# Altium schematic sheet Sheet1.SchDoc
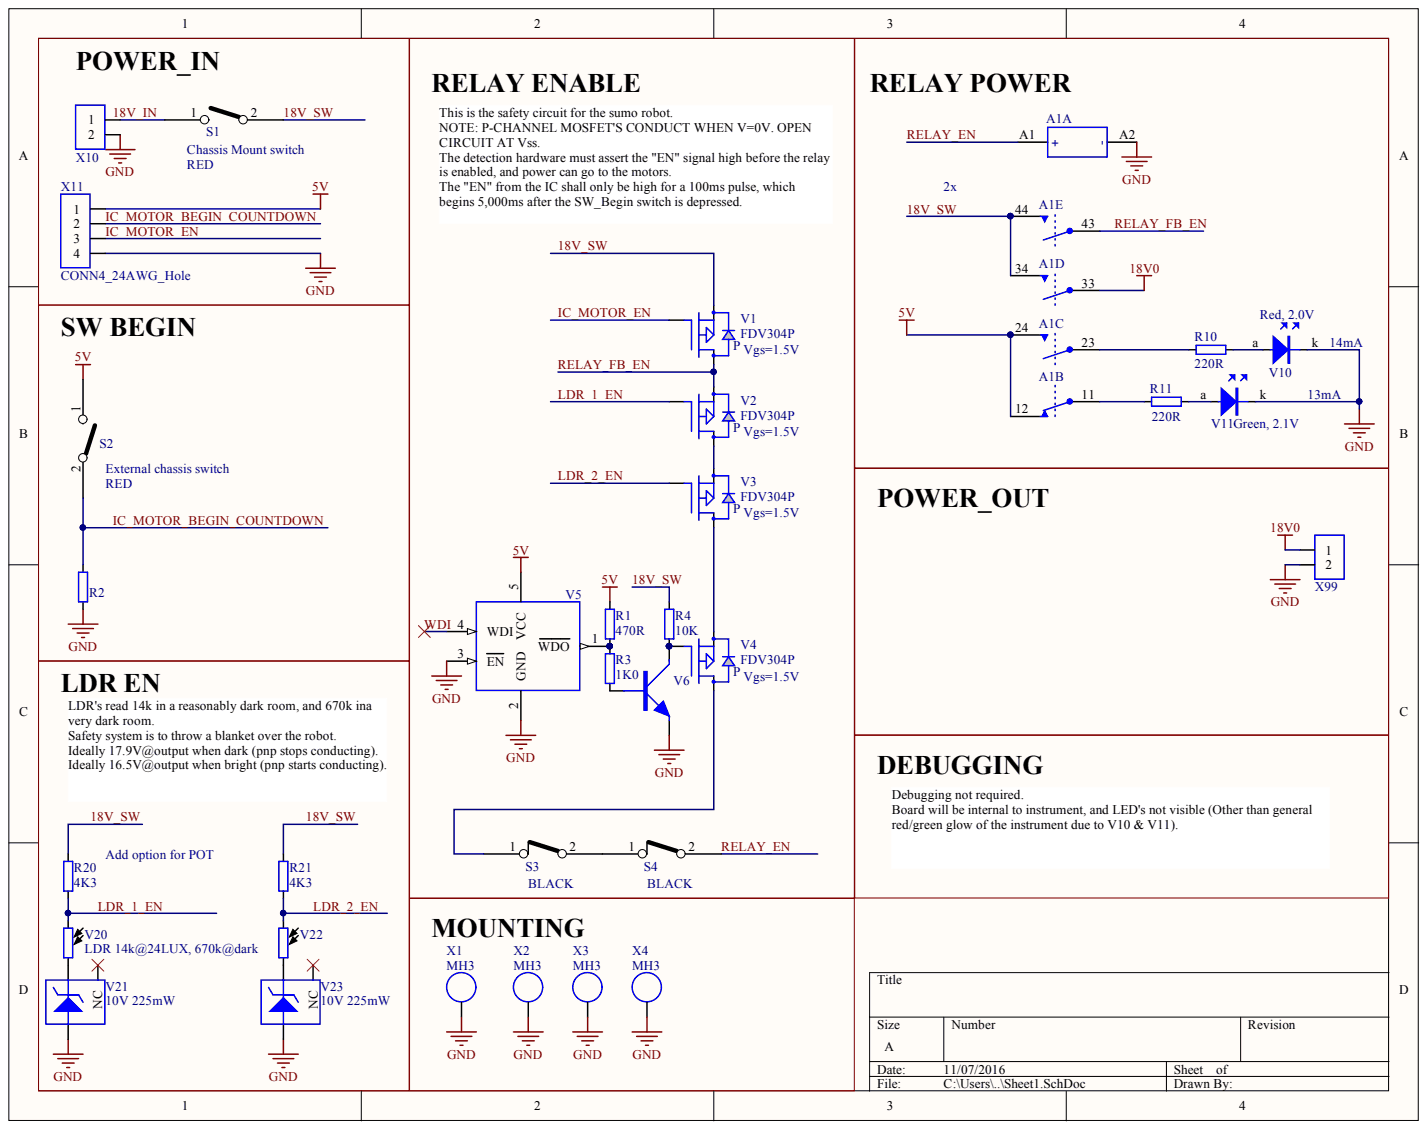
[diagram p0: Sheet1.SchDoc]

## Parts
A1  RELAY SAFETY 4PST 8A 18V  [RELAY-BRUMFIELD-4PST-3NO-1NC]  (value not drawn)  (parts A,B,C,D,E)
R1  470R  [1206]
R2  10K  [1206]  (value not drawn)
R3  1K0  [1206]
R4  10K  [1206]
R10  220R  [1206]
R11  220R  [1206]
R20  4K3  [0603]
R21  4K3  [0603]
S1  SWITCH Rocker SPST Panel Mount, Red  [2_Wire_12AWG]  (value not drawn)
S2  Switch NO  [2_Wire_12AWG]  (value not drawn)
S3  Switch NC  [2_Wire_20AWG]  (value not drawn)
S4  Switch NC  [2_Wire_20AWG]  (value not drawn)
V1  FDV304P  [SOT23]
V2  FDV304P  [SOT23]
V3  FDV304P  [SOT23]
V4  FDV304P  [SOT23]
V5  STWD100NYWY3F  [SOT23-5]  (value not drawn)
V6  BC846B  [SOT23]  (value not drawn)
V10  Red, 2.0V  [LED-0805]
V11  Green, 2.1V  [LED-0805]
V20  LDR 14k@24LUX, 670k@dark  [LDR_NSL-5112]
V21  10V 225mW  [SOT23]
V22  LDR 14k@24LUX, 670k@dark  [LDR_NSL-5112]
V23  10V 225mW  [SOT23]
X1  MH3  [MH-M3-SEMS-6.7-3.6-Vias-Unplated]  (no BOM)
X2  MH3  [MH-M3-SEMS-6.7-3.6-Vias-Unplated]  (no BOM)
X3  MH3  [MH-M3-SEMS-6.7-3.6-Vias-Unplated]  (no BOM)
X4  MH3  [MH-M3-SEMS-6.7-3.6-Vias-Unplated]  (no BOM)
X10  CONN2_12AWG_Hole  [2_Wire_12AWG]  (value not drawn)  (no BOM)
X11  CONN4_24AWG_Hole  [4_Wire_0.1"]  (no BOM)
X99  CONN2_12AWG_Hole  [2_Wire_12AWG]  (value not drawn)  (no BOM)

## Nets
5V: A1.12 A1.24 R1.1 S2.1 V5.5(VCC) X11.1
18V0: A1.33 X99.1
GND: A1.A2 R2.1 V5.2(GND) V5.3(EN) V6.y V10.k V11.k V21.z V23.z X1.1 X2.1 X3.1 X4.1 X10.2 X11.4 X99.2
18V_SW: A1.34 A1.44 R4.2 R20.2 R21.2 S1.2 V1.y
IC_MOTOR_BEGIN_COUNTDOWN: R2.2 S2.2 X11.2
IC_MOTOR_EN: V1.z X11.3
LDR_1_EN: R20.1 V2.z V20.1
LDR_2_EN: R21.1 V3.z V22.1
RELAY_EN: A1.A1(+) S4.2
RELAY_FB_EN: A1.43 V1.x V2.y
WDI: V5.4(WDI)
NetA1_11: A1.11 R11.1
NetA1_23: A1.23 R10.1
NetR1_2: R1.2 R3.2 V5.1(WDO)
NetR3_1: R3.1 V6.z
NetR4_1: R4.1 V4.z V6.x
NetR10_2: R10.2 V10.a
NetR11_2: R11.2 V11.a
NetS1_1: S1.1 X10.1
NetS3_1: S3.1 V4.x
NetS3_2: S3.2 S4.1
NetV2_x: V2.x V3.y
NetV3_x: V3.x V4.y
NetV20_2: V20.2 V21.x
NetV22_2: V22.2 V23.x
18V_IN:

Not connected: V21 y; V23 y

## Library data of the parts
A1 = 9827: Safety Relay 4PST, 3NO 1 NC; Manufacturer: TE Connectivity Potter & Brumfield Relays; Characteristics: 18VDC 405ohm  1250VAC/8A-Switching-load; Database Table Name: Active; DeviceRank: 2; Lead_Process_Compatible: Yes; OrderNumber: SR4M4018; SubmittedBy: zmze; Symbol: RELAY-4PST-3A1B; Altium_Comment: RELAY SAFETY 4PST 8A 18V; Altium_Comment_Old: RELAY SAFETY 4PST 8A 18V; Component Kind: Standard; ComponentLink1Description: TE Connectivity Potter & Brumfield Relays_SR4M4018_ENG_DS_SR4_DXM_0415.pdf; ComponentLink1URL: http://ecad.vsl.com.au/apps/BomGen/Data/rel/datasheets/TE Connectivity Potter & Brumfield Relays/TE Connectivity Potter & Brumfield Relays_SR4M4018_ENG_DS_SR4_DXM_0415.pdf; ComponentLink2Description: Compliant; IPN: 9827; IPN_Search: 9827; LastChanged: 27/05/16 08:10:39; Part Field 1: RELAY SAFETY 4PST 8A 18V; Part Field 2: TE Connectivity Potter & Brumfield Relays SR4M4018; PartNumber: SR4M4018; PartType: PCB; Supplier1: Digikey; Supplier1_PartNumber: PB1304-ND; Library Name: SVN_BOMGEN_Database_2014.DbLib
R1 = 3835: Resistor; Manufacturer: Phycomp; Part Field 1: RES 470R 1% 250mW 1206 RoHS; PartNumber: RC1206FR-07470RL; Characteristics: 100ppm/C -55..+155C U=150Vrms Reel=5000pcs; Database Table Name: Active; DeviceRank: 2; EndOfLife: Active EOL:Jan 2023; Lead_Process_Compatible: Yes; OrderNumber: 232272464701L; SubmittedBy: ART; Symbol: RES; Altium_Comment: 470R; Altium_Comment_Old: RES 470R 1% 250mW 1206 RoHS; Component Kind: Standard; ComponentLink1Description: Phycomp_RC1206series_DS.pdf; ComponentLink1URL: http://ecad.vsl.com.au/apps/BomGen/Data/rel/datasheets/new_parts/Phycomp_RC1206series_DS.pdf; ComponentLink2Description: Phycomp_Generic_RCS.pdf; ComponentLink2URL: http://ecad.vsl.com.au/apps/BomGen/Data/rel/rohs_compliance/new_certificates/Phycomp_Generic_RCS.pdf; IPN: 3835; IPN_Search: 3835; Part Field 2: Phycomp RC1206FR-07470RL; PartType: PCB; Price_5000: M$0.004; Supplier1: Arrow; Supplier1_PartNumber: RC1206FR-07470RL; Supplier2: Xon; CheckedBy: ART; Library Name: SVN_BOMGEN_Database_2014.DbLib
R2 R4 = 3867: Resistor; Manufacturer: Phycomp; Part Field 1: RES 10K 1% 250mW 1206 RoHS; PartNumber: RC1206FR-0710KL; Characteristics: 100ppm/C -55..+155C U=150Vrms Reel=5000pcs; Database Table Name: Active; DeviceRank: 2; EndOfLife: Active EOL:Jan 2031; Lead_Process_Compatible: Yes; OrderNumber: 232272461003L; SubmittedBy: ART; Symbol: RES; Altium_Comment: 10K; Altium_Comment_Old: RES 10K 1% 250mW 1206 RoHS; Component Kind: Standard; ComponentLink1Description: Phycomp_RC1206series_DS.pdf; ComponentLink1URL: http://ecad.vsl.com.au/apps/BomGen/Data/rel/datasheets/new_parts/Phycomp_RC1206series_DS.pdf; ComponentLink2Description: Phycomp_Generic_RCS.pdf; ComponentLink2URL: http://ecad.vsl.com.au/apps/BomGen/Data/rel/rohs_compliance/new_certificates/Phycomp_Generic_RCS.pdf; IPN: 3867; IPN_Search: 3867; Part Field 2: Phycomp RC1206FR-0710KL; PartType: PCB; Price_5000: M$0.004; Supplier1: Arrow; Supplier1_PartNumber: RC1206FR-0710KL; Supplier2: Xon; CheckedBy: ART; Library Name: SVN_BOMGEN_Database_2014.DbLib
R3 = 3843: Resistor; Manufacturer: Phycomp; Part Field 1: RES 1K0 1% 250mW 1206 RoHS; PartNumber: RC1206FR-071KL; Characteristics: 100ppm/C -55..+155C U=150Vrms Reel=5000pcs; Database Table Name: Active; DeviceRank: 2; EndOfLife: Active EOL:Jan 2031; Lead_Process_Compatible: Yes; OrderNumber: 232272461002L; SubmittedBy: ART; Symbol: RES; Altium_Comment: 1K0; Altium_Comment_Old: RES 1K0 1% 250mW 1206 RoHS; Component Kind: Standard; ComponentLink1Description: Phycomp_RC1206series_DS.pdf; ComponentLink1URL: http://ecad.vsl.com.au/apps/BomGen/Data/rel/datasheets/new_parts/Phycomp_RC1206series_DS.pdf; ComponentLink2Description: Phycomp_Generic_RCS.pdf; ComponentLink2URL: http://ecad.vsl.com.au/apps/BomGen/Data/rel/rohs_compliance/new_certificates/Phycomp_Generic_RCS.pdf; IPN: 3843; IPN_Search: 3843; Part Field 2: Phycomp RC1206FR-071KL; PartType: PCB; Price_5000: M$0.004; Supplier1: Arrow; Supplier1_PartNumber: RC1206FR-071KL; Supplier2: Xon; CheckedBy: ART; Library Name: SVN_BOMGEN_Database_2014.DbLib
R10 R11 = 3827: Resistor; Manufacturer: Phycomp; Part Field 1: RES 220R 1% 250mW 1206 RoHS; PartNumber: RC1206FR-07220RL; Characteristics: 100ppm/C -55..+155C U=150Vrms Reel=5000pcs; Database Table Name: Active; DeviceRank: 2; EndOfLife: Active EOL:Jan 2023; Lead_Process_Compatible: Yes; OrderNumber: 232272462201L; SubmittedBy: ART; Symbol: RES; Altium_Comment: 220R; Altium_Comment_Old: RES 220R 1% 250mW 1206 RoHS; Component Kind: Standard; ComponentLink1Description: Phycomp_RC1206series_DS.pdf; ComponentLink1URL: http://ecad.vsl.com.au/apps/BomGen/Data/rel/datasheets/new_parts/Phycomp_RC1206series_DS.pdf; ComponentLink2Description: Phycomp_Generic_RCS.pdf; ComponentLink2URL: http://ecad.vsl.com.au/apps/BomGen/Data/rel/rohs_compliance/new_certificates/Phycomp_Generic_RCS.pdf; IPN: 3827; IPN_Search: 3827; Part Field 2: Phycomp RC1206FR-07220RL; PartType: PCB; Price_5000: M$0.004; Supplier1: Arrow; Supplier1_PartNumber: RC1206FR-07220RL; Supplier2: Xon; CheckedBy: ART; Library Name: SVN_BOMGEN_Database_2014.DbLib
R20 R21 = 3520: Resistor; Manufacturer: Phycomp; PartNumber: RC0603FR-074K3L; AltDesc1: RES 4K3 1% 100mW 0603 RoHS; AltEOL1: Active EOL:Jan 2023; AltIPN1: 6663; AltMFG1: PANASONIC; AltPN1: ERJ3EKF4301V; Characteristics: 100ppm/C -55..+155C U=50Vrms Reel=5000pcs; Database Table Name: Active; DeviceRank: 1; Lead_Process_Compatible: Yes; OrderNumber: 232270464302L; SubmittedBy: ART; Symbol: RES; Altium_Comment: 4K3; Altium_Comment_Old: RES 4K3 1% 100mW 0603 RoHS; AltROHS1: pa003.pdf; Component Kind: Standard; ComponentLink1Description: Phycomp_RC0603series_DS.pdf; ComponentLink1URL: http://ecad.vsl.com.au/apps/BomGen/Data/rel/datasheets/new_parts/Phycomp_RC0603series_DS.pdf; ComponentLink2Description: Phycomp_Generic_RCS.pdf; ComponentLink2URL: http://ecad.vsl.com.au/apps/BomGen/Data/rel/rohs_compliance/new_certificates/Phycomp_Generic_RCS.pdf; EndOfLife: Active EOL:Jan 2023; IPN: 3520; IPN_Search: 3520; LastChanged: 28/11/07 14:44:00; Part Field 1: RES 4K3 1% 100mW 0603 RoHS; Part Field 2: Phycomp RC0603FR-074K3L; PartType: PCB; Price_5000: M$0.002; Supplier1: Arrow; Supplier1_PartNumber: RC0603FR-074K3L; Supplier2: Xon; Supplier2_PartNumber: 232270464302L; CheckedBy: ART; Library Name: SVN_BOMGEN_Database_2014.DbLib
S1 = 9815: SWITCH; Manufacturer: Cherry; Characteristics: V=125Vac, I=20A; Database Table Name: Active; DeviceRank: 2; Lead_Process_Compatible: Yes; OrderNumber: CRE22F2BBRLE; SubmittedBy: presi; Symbol: Switch_SPST-NO; Altium_Comment: SWITCH Rocker SPST Panel Mount, Red; Altium_Comment_Old: SWITCH Rocker SPST Panel Mount, Red; Component Kind: Standard; ComponentLink1Description: -; ComponentLink1URL: -; ComponentLink2Description: Compliant; ComponentLink2URL: -; IPN: 9815; IPN_Search: 9815; LastChanged: 13-06-16 21:14:44; Part Field 1: SWITCH Rocker SPST Panel Mount, Red; Part Field 2: Cherry CRE22F2BBRLE; PartNumber: CRE22F2BBRLE; PartType: PCB; Supplier1: DigiKey; Supplier1_PartNumber: CH809-ND; Library Name: SVN_BOMGEN_Database_2014.DbLib
S2 = 9814: SWITCH; Manufacturer: TE Connectivity; Part Field 1: Switch, Panel Mount, Momentary, SPST-NO, 0.5A 125V, RoHS; Characteristics: 0.5A 125V; Database Table Name: Active; DeviceRank: 2; Lead_Process_Compatible: Yes; OrderNumber: 1437568-6; SubmittedBy: presi; Symbol: Switch_SPST-NO; Altium_Comment: Switch NO; Altium_Comment_Old: Switch, Panel Mount, Momentary, SPST-NO, 0.5A 125V, RoHS; Component Kind: Standard; ComponentLink1Description: -; ComponentLink1URL: -; ComponentLink2Description: Compliant; ComponentLink2URL: -; IPN: 9814; IPN_Search: 9814; LastChanged: 13-06-16 21:00:15; Part Field 2: TE Connectivity 1437568-6; PartNumber: 1437568-6; PartType: PCB; Supplier1: Digi-Key; Supplier1_PartNumber: 450-1110-ND; Library Name: SVN_BOMGEN_Database_2014.DbLib
S3 S4 = 9813: SWITCH; Manufacturer: TE Connectivity; Part Field 1: Switch, Panel Mount, Momentary, SPST-NC, 0.5A 125V, RoHS; Characteristics: 0.5A 125V; Database Table Name: Active; DeviceRank: 2; Lead_Process_Compatible: Yes; OrderNumber: MSPS103B; SubmittedBy: presi; Symbol: Switch_SPST-NC; Altium_Comment: Switch NC; Altium_Comment_Old: Switch, Panel Mount, Momentary, SPST-NC, 0.5A 125V, RoHS; Component Kind: Standard; ComponentLink1Description: -; ComponentLink1URL: -; ComponentLink2Description: Compliant; ComponentLink2URL: -; IPN: 9813; IPN_Search: 9813; LastChanged: 13-06-16 20:48:49; Part Field 2: TE Connectivity MSPS103B; PartNumber: MSPS103B; PartType: PCB; Supplier1: Digi-Key; Supplier1_PartNumber: 450-1099-ND; Library Name: SVN_BOMGEN_Database_2014.DbLib
V1-V4 = 5221: MOSFET P CHANNEL GENERIC SOT23; Manufacturer: Fairchild; Part Field 1: MOSFET P-CH FDV304P SOT23; Characteristics: 25V 0.46A 1.5R Vgs=1.5V 150deg; Database Table Name: Active; DeviceRank: 2; EndOfLife: Active EOL:Jul 2022; Lead_Process_Compatible: Yes; SubmittedBy: KZB; Symbol: MOSFET-P-SOT23; Altium_Comment: FDV304P; Altium_Comment_Old: MOSFET P-CH FDV304P SOT23; Component Kind: Standard; ComponentLink1Description: Fairchild_FDV304P_DS.pdf; ComponentLink1URL: http://ecad.vsl.com.au/apps/BomGen/Data/rel/datasheets/new_parts/Fairchild_FDV304P_DS.pdf; ComponentLink2Description: Fairchild_Generic_RCS.pdf; ComponentLink2URL: http://ecad.vsl.com.au/apps/BomGen/Data/rel/rohs_compliance/new_certificates/Fairchild_Generic_RCS.pdf; IPN: 5221; IPN_Search: 5221; LastChanged: 10/06/10 13:58:43; OrderNumber: FDV304P; Part Field 2: Fairchild FDV304P; PartNumber: FDV304P; PartType: PCB; Price: 0.697; Price_100: M$0.102; Price_1000: M$0.070; Price_5000: M$0.053; Supplier1: Digikey; Supplier1_PartNumber: FDV304PCT-ND; Supplier2: Farnell; Supplier2_PartNumber: 9846123; CheckedBy: AJR; Library Name: SVN_BOMGEN_Database_2014.DbLib
V5 = 5674: IC Watchdog Timer Circuit; Manufacturer: ST Microelectronics; Part Field 1: Microprocessor Watchdog Timer STWD100NY; Characteristics: Open Drain Output 210ms; Database Table Name: Active; DeviceRank: 2; EndOfLife: Active EOL:Dec 2024; Lead_Process_Compatible: Yes; SubmittedBy: GRH; Altium_Comment: STWD100NYWY3F; Altium_Comment_Old: Microprocessor Watchdog Timer STWD100NY; Component Kind: Standard; ComponentLink1Description: STWD100.pdf; ComponentLink1URL: http://ecad.vsl.com.au/apps/BomGen/Data/rel/datasheets/new_parts/STWD100.pdf; ComponentLink2Description: ROHSSTWD100NYWY3F.pdf; ComponentLink2URL: http://ecad.vsl.com.au/apps/BomGen/Data/rel/rohs_compliance/new_certificates/ROHSSTWD100NYWY3F.pdf; IPN: 5674; IPN_Search: 5674; LastChanged: 20/04/11 08:20:11; Part Field 2: ST Microelectronics STWD100NYWY3F; PartNumber: STWD100NYWY3F; PartType: PCB; Price: 1.40 (USD); Price_100: M$0.611; Price_1000: M$0.426; Price_5000: M$0.378; Supplier1: Digikey; Supplier1_PartNumber: 497-10058-1-ND; Symbol: STWD100; CheckedBy: SAA; Library Name: SVN_BOMGEN_Database_2014.DbLib
V6 = 3412: NPN Transistor SOT23; Manufacturer: NXP; Part Field 1: BJT NPN BC846 SOT23 RoHS; Characteristics: Ic=100mA Vceo=65V Pt=250mW Tj=150degC Hfe=200..450; Database Table Name: Active; DeviceRank: 1; EndOfLife: Active EOL:Nov 2023; Lead_Process_Compatible: Yes; OrderNumber: 9335 895 60215; SubmittedBy: ART; Symbol: NPN-SOT23; Altium_Comment: BC846B; Altium_Comment_Old: BJT NPN BC846 SOT23 RoHS; Component Kind: Standard; ComponentLink1Description: NXP_BC84xseries_DS.pdf; ComponentLink1URL: http://ecad.vsl.com.au/apps/BomGen/Data/rel/datasheets/new_parts/NXP_BC84xseries_DS.pdf; ComponentLink2Description: NXP_BC846B_MD.xls; ComponentLink2URL: Not Found; IPN: 3412; IPN_Search: 3412; LastChanged: 24/08/09 11:56:43; Part Field 2: NXP BC846B; PartNumber: BC846B; PartType: PCB; Price_100: M$0.040; Price_1000: M$0.027; Price_5000: M$0.020; Supplier1: Mouser; Supplier1_PartNumber: 771-BC846B-T/R; Supplier2: Digikey; Supplier2_PartNumber: 568-1632-1-ND; CheckedBy: LZZ; Library Name: SVN_BOMGEN_Database_2014.DbLib
V10 = 2785: LED; Manufacturer: Kingbright; Part Field 1: LED Red 12mcd@20mA 0805 RoHS; Characteristics: Wpk=627nm Vftyp=2V Vfmax=2.5V Ifmax=30mA -40..+85 View angle=120deg; Database Table Name: Active; DeviceRank: 2; EndOfLife: Active EOL:Jun 2020; Lead_Process_Compatible: Yes; OrderNumber: KP-2012EC; SubmittedBy: LGG; Altium_Comment: LED Red 12mcd@20mA 0805 RoHS; Altium_Comment_Old: LED Red 12mcd@20mA 0805 RoHS; Component Kind: Standard; ComponentLink1Description: Kingbright_KP-2012EC_DS.pdf; ComponentLink1URL: http://ecad.vsl.com.au/apps/BomGen/Data/rel/datasheets/new_parts/Kingbright_KP-2012EC_DS.pdf; ComponentLink2Description: Kingbright_KPseries_RCS.pdf; ComponentLink2URL: http://ecad.vsl.com.au/apps/BomGen/Data/rel/rohs_compliance/new_certificates/Kingbright_KPseries_RCS.pdf; IPN: 2785; IPN_Search: 2785; LastChanged: 27/06/08 15:06:33; Part Field 2: Kingbright KP-2012EC; PartNumber: KP-2012EC; PartType: PCB; Price: 0.08; Supplier1: Tenrod; Supplier1_PartNumber: KP-2012EC; Supplier2: Farnell; Supplier2_PartNumber: 8529949; Symbol: LED; CheckedBy: ART; Library Name: SVN_BOMGEN_Database_2014.DbLib
V11 = 6313: LED; Manufacturer: Chicago Miniature Lighting; Part Field 1: LED GREEN 0805 ROHS; Characteristics: GREEN Intensity=15mcd Ta=-40..+85C; Database Table Name: Active; DeviceRank: 2; EndOfLife: Active EOL:Sep 2021; Lead_Process_Compatible: Yes; OrderNumber: CMD17-21UGC/TR8; SubmittedBy: ZJXL; Altium_Comment: LED Green; Altium_Comment_Old: LED GREEN 0805 ROHS; Component Kind: Standard; ComponentLink1Description: 1-25.pdf; ComponentLink1URL: \\michelangelo\erg shared folder\ECAD\elecCAD\apps\BomGen\Data\rel\datasheets\new_parts\1-25.pdf; ComponentLink2Description: CMD17-21UGC_TR8 RoHS CoC.pdf; ComponentLink2URL: \\michelangelo\erg shared folder\ECAD\elecCAD\apps\BomGen\Data\rel\rohs_compliance\new_certificates\CMD17-21UGC_TR8 RoHS CoC.pdf; IPN: 6313; IPN_Search: 6313; LastChanged: 6/09/13 17:30:23; Part Field 2: Chicago Miniature Lighting CMD17-21UGC/TR8; PartNumber: CMD17-21UGC/TR8; PartType: PCB; Price: 0.279 USD; Price_100: M$0.170; Price_1000: M$0.150; Price_5000: M$0.150; Supplier1: Mouser; Supplier1_PartNumber: 606-CMD17-21UGC; Supplier2: DIGIKEY; Supplier2_PartNumber: L62415CT-ND; Symbol: LED; CheckedBy: SAA; Library Name: SVN_BOMGEN_Database_2014.DbLib
V20 V22 = 9828: LDR; Manufacturer: Luna Optoelectronics; Part Field 1: LDR Photocell, 14k@24LUX, 670k@dark; Characteristics: 550nm, 100vPk, -65...+75C, 50mW max,; Database Table Name: Active; DeviceRank: 2; Lead_Process_Compatible: Yes; SubmittedBy: zmze; Altium_Comment: LDR 14k@24LUX, 670k@dark; Altium_Comment_Old: LDR Photocell, 14k@24LUX, 670k@dark; Component Kind: Standard; ComponentLink1Description: Luna Optoelectronics_NSL-5112_NSL-5112.pdf; ComponentLink1URL: http://ecad.vsl.com.au/apps/BomGen/Data/rel/datasheets/Luna Optoelectronics/Luna Optoelectronics_NSL-5112_NSL-5112.pdf; ComponentLink2Description: Non_compliant; IPN: 9828; IPN_Search: 9828; LastChanged: 27/05/16 08:14:08; OrderNumber: NSL-5112; Part Field 2: Luna Optoelectronics NSL-5112; PartNumber: NSL-5112; PartType: PCB; Supplier1: Digikey; Supplier1_PartNumber: NSL-5112-ND; Symbol: LDR; Library Name: SVN_BOMGEN_Database_2014.DbLib
V21 V23 = 6327: DIODE ZENER SOT23 a-z k-x nc-y; Manufacturer: ON Semi; Part Field 1: DIODE ZENER 10V 5% 225mW SOT23 RoHS; Characteristics: Vf=900mV Zzt=20R -65..+150C Reel=3000; Database Table Name: Active; DeviceRank: 2; Lead_Process_Compatible: Yes; OrderNumber: BZX84C10LT1G; SubmittedBy: VQD; Symbol: ZENER-SOT23; Altium_Comment: 10V 225mW; Altium_Comment_Old: DIODE ZENER 10V 5% 225mW SOT23 RoHS; Component Kind: Standard; ComponentLink1Description: ON Semi_BZX84C10LT1G_BZX84 Series.pdf; ComponentLink1URL: http://ecad.vsl.com.au/apps/BomGen/Data/rel/datasheets/ON Semi/ON Semi_BZX84C10LT1G_BZX84 Series.pdf; ComponentLink2Description: ON Semi_BZX84C10LT1G_BZX84C10LT1G RoHS Cert.pdf; ComponentLink2URL: http://ecad.vsl.com.au/apps/BomGen/Data/rel/rohs_compliance/new_certificates/ON Semi_BZX84C10LT1G_BZX84C10LT1G RoHS Cert.pdf; IPN: 6327; IPN_Search: 6327; LastChanged: 07/07/14 15:36:02; Part Field 2: ON Semi BZX84C10LT1G; PartNumber: BZX84C10LT1G; PartType: PCB; Price: 0.08990; Supplier1: Digikey; Supplier1_PartNumber: BZX84C10LT1GOSTR-ND; Library Name: SVN_BOMGEN_Database_2014.DbLib
X1-X4 = 7545: MOUNTING HOLE; Part Field 1: MH3 Loose Unplated with Vias; Database Table Name: Active; DeviceRank: 2; Lead_Process_Compatible: Yes; SubmittedBy: zad; Symbol: MOUNTING-HOLE; Altium_Comment: MH3; Altium_Comment_Old: MH3 Loose Unplated with Vias; ComponentLink1Description: -; ComponentLink1URL: -; ComponentLink2Description: Compliant; ComponentLink2URL: -; IPN: 7545; IPN_Search: 7545; LastChanged: 24/06/14 18:13:23; Manufacturer: -; OrderNumber: -; Part Field 2: - -; PartNumber: -; PartType: PCB; CheckedBy: vhq; Library Name: SVN_BOMGEN_Database_2014.DbLib
X10 X99 = -: DeviceRank: 2; Lead_Process_Compatible: Yes; SubmittedBy: ZMZE; Symbol: CONN2; Altium_Comment: CONN2_12AWG_Hole; Altium_Comment_Old: CONN2_12AWG_Hole; Characteristics: -; Description: CONN2_12AWG_Hole; IPN: -; IPN_Search: -; LastChanged: 27/09/12 10:20:42; Manufacturer: -; OrderNumber: -; Part Field 1: CONN2_12AWG_Hole; Part Field 2: -; PartNumber: -; PartType: PCB; Price: -; Price_100: -; Price_1000: -; Price_5000: -; Supplier1: -; Supplier1_PartNumber: -; Supplier2: -; Supplier2_PartNumber: -; CheckedBy: -; Library Name: Sumo_2016.IntLib
X11 = CONN4: Altium_Comment: CONN4_24AWG_Hole; Description: CONN4_24AWG_Hole; Library Name: Sumo_2016.IntLib
